# Altium schematic sheet RP2350.SchDoc
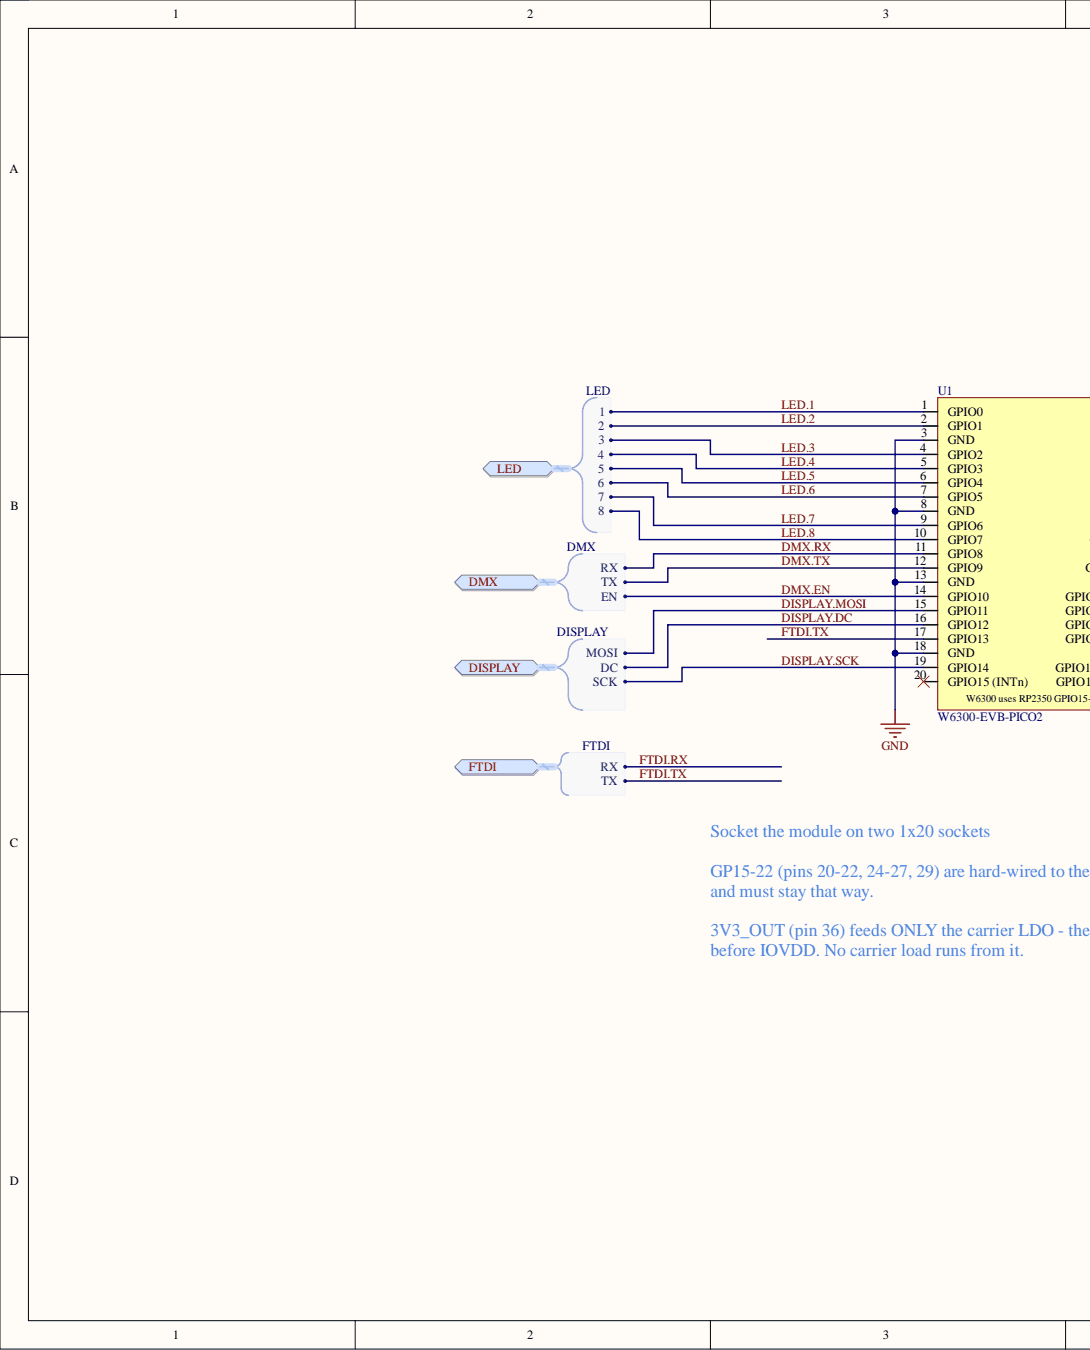
[diagram p0: RP2350.SchDoc, left]
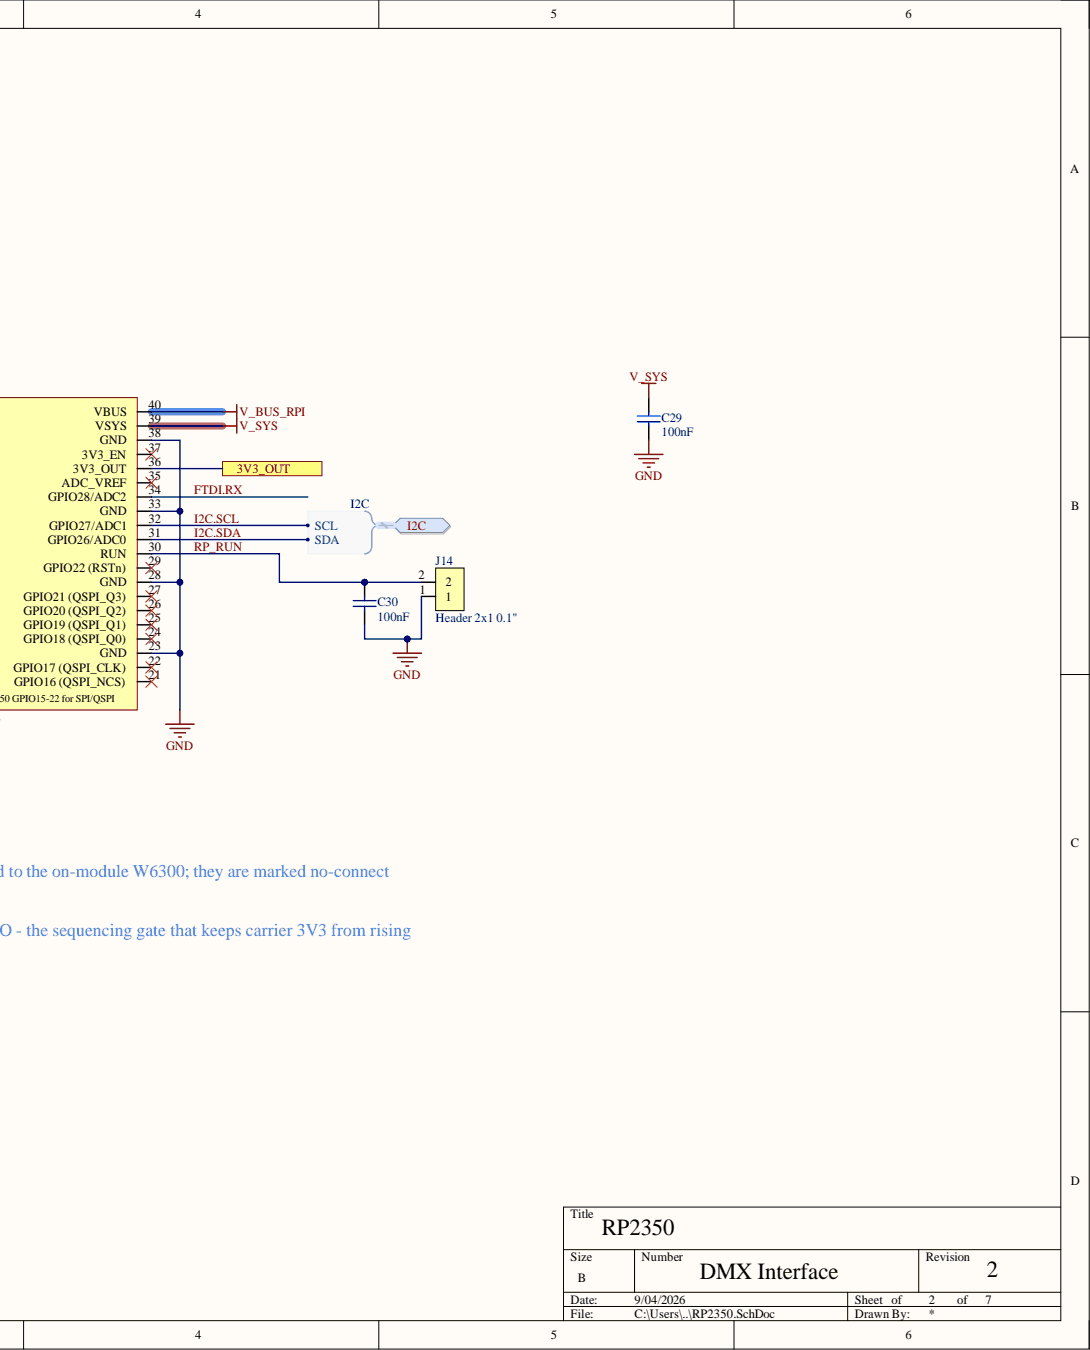
[diagram p1: RP2350.SchDoc, right]

## Parts
C29  value 100nF  [CAPC1608X10N_0603_MED]  (hidden comment: CC0603KRX7R0BB104)
C30  value 100nF  [CAPC1608X10N_0603_MED]  (hidden comment: CC0603KRX7R0BB104)
J14  Header 2x1 0.1"  [FP-M20-9990245-MFG]
U1  W6300-EVB-PICO2  [W6300-EVB-PICO2_0.4375_standoff]

## Ports
3V3_OUT, DISPLAY, DISPLAY.DC, DISPLAY.MOSI, DISPLAY.SCK, DMX, DMX.EN, DMX.RX, DMX.TX, FTDI, FTDI.RX, FTDI.TX, I2C, I2C.SCL, I2C.SDA, LED, LED.1, LED.2, LED.3, LED.4, LED.5, LED.6, LED.7, LED.8

## Nets
GND: C29.2 C30.2 J14.1 U1.3(GND) U1.8(GND) U1.13(GND) U1.18(GND) U1.23(GND) U1.28(GND) U1.33(GND) U1.38(GND)
V_BUS_RPI: U1.40(VBUS)
V_SYS: C29.1 U1.39(VSYS)
3V3_OUT: U1.36(3V3_OUT) ; port 3V3_OUT
DISPLAY.DC: U1.16(GPIO12) ; port DISPLAY
DISPLAY.MOSI: U1.15(GPIO11) ; port DISPLAY
DISPLAY.SCK: U1.19(GPIO14) ; port DISPLAY
DMX.EN: U1.14(GPIO10) ; port DMX
DMX.RX: U1.11(GPIO8) ; port DMX
DMX.TX: U1.12(GPIO9) ; port DMX
FTDI.RX: U1.34(GPIO28/ADC2) ; port FTDI
FTDI.TX: U1.17(GPIO13) ; port FTDI
I2C.SCL: U1.32(GPIO27/ADC1) ; port I2C
I2C.SDA: U1.31(GPIO26/ADC0) ; port I2C
LED.1: U1.1(GPIO0) ; port LED
LED.2: U1.2(GPIO1) ; port LED
LED.3: U1.4(GPIO2) ; port LED
LED.4: U1.5(GPIO3) ; port LED
LED.5: U1.6(GPIO4) ; port LED
LED.6: U1.7(GPIO5) ; port LED
LED.7: U1.9(GPIO6) ; port LED
LED.8: U1.10(GPIO7) ; port LED
RP_RUN: C30.1 J14.2 U1.30(RUN)
NetU1_20: U1.20(GPIO15_(INTn))
NetU1_21: U1.21(GPIO16_(QSPI_NCS))
NetU1_22: U1.22(GPIO17_(QSPI_CLK))
NetU1_24: U1.24(GPIO18_(QSPI_Q0))
NetU1_25: U1.25(GPIO19_(QSPI_Q1))
NetU1_26: U1.26(GPIO20_(QSPI_Q2))
NetU1_27: U1.27(GPIO21_(QSPI_Q3))
NetU1_29: U1.29(GPIO22_(RSTn))
NetU1_35: U1.35(ADC_VREF)
NetU1_37: U1.37(3V3_EN)

## Library data of the parts
C29 C30 = CMP-001-00102-4: Ceramic Capacitor, Multilayer, Ceramic, 100V, 10% +Tol, 10% -Tol, X7R, 15% TC, 0.1uF, Surface Mount, 0603; Capacitor Type: Ceramic; Case/Package: 0603; Component Kind: Standard; JLCPN: C113803; Max Operating Temperature: 125°C; Min Operating Temperature: -55°C; Mounting Technology: Surface Mount; Pins: 2; RoHS Compliant: Yes; Voltage Rating: 100V; Library Name: Equus Computer Systems
J14 = CMP-002-00082-2: Conn Header Vert 2POS 2.54MM; Connector Type: BOARD CONNECTOR; Component Kind: Standard; Max Operating Temperature: 105°C; Min Operating Temperature: -40°C; Mounting Technology: Through Hole; Pins: 2; RoHS Compliant: Yes; Library Name: Equus Computer Systems
U1 = CMP-020-00030-3: Raspberry Pi RP2350 and fully hardwired TCP/IP controller W6300; Component Kind: Standard; Library Name: Equus Computer Systems
